# Altium schematic sheet 03_ANALOG.SchDoc
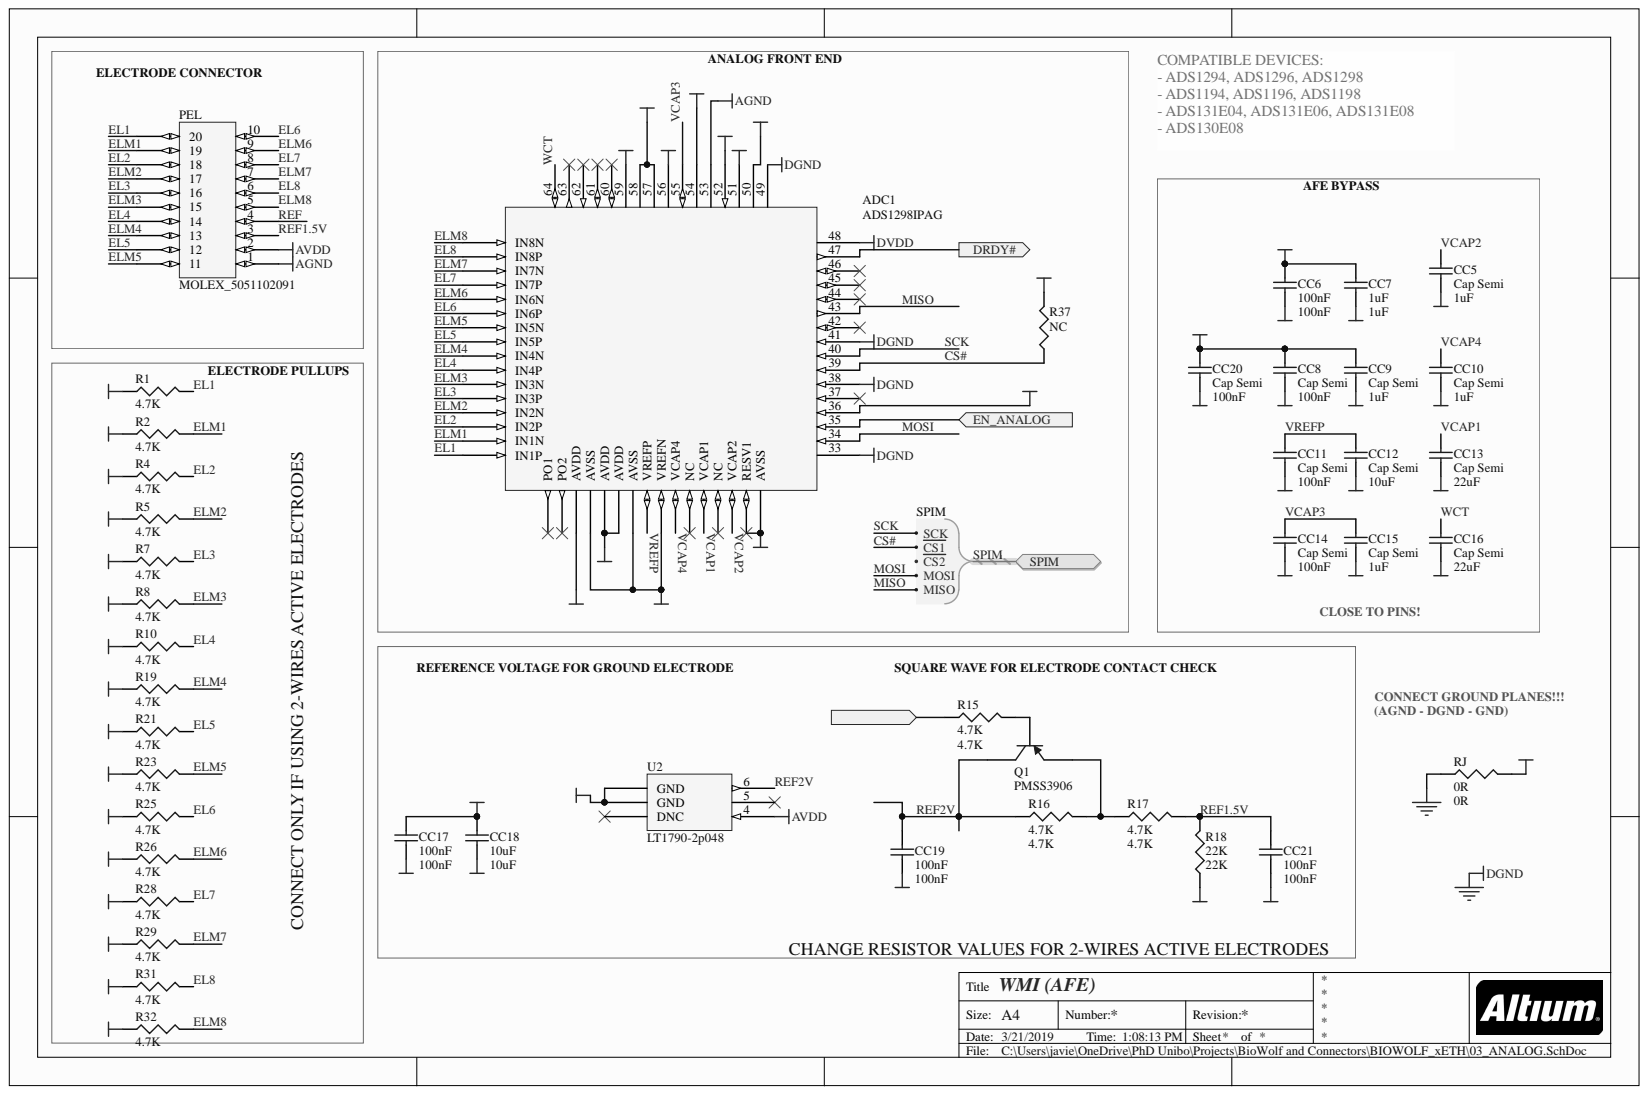
[diagram p0: 03_ANALOG.SchDoc]

## Parts
ADC1  ADS1298IPAG  [LQFP64_M]
CC5  Cap Semi  value 1uF  [0402]
CC6  100nF  [0402]
CC7  1uF  [0402]
CC8  Cap Semi  value 100nF  [0402]
CC9  Cap Semi  value 1uF  [0402]
CC10  Cap Semi  value 1uF  [0402]
CC11  Cap Semi  value 100nF  [0402]
CC12  Cap Semi  value 10uF  [0402]
CC13  Cap Semi  value 22uF  [J1-0603]
CC14  Cap Semi  value 100nF  [0402]
CC15  Cap Semi  value 1uF  [0402]
CC16  Cap Semi  value 22uF  [1608[0603]]
CC17  100nF  [0402]
CC18  10uF  [0402]
CC19  100nF  [0402]
CC20  Cap Semi  value 100nF  [0402]
CC21  100nF  [0402]
PEL  MOLEX_5051102091  [MOLEX_5051102091]
Q1  PMSS3906  [SOT323_N]
R1  4.7K  [0402]
R2  4.7K  [0402]
R4  4.7K  [0402]
R5  4.7K  [0402]
R7  4.7K  [0402]
R8  4.7K  [0402]
R10  4.7K  [0402]
R15  4.7K  [0402]
R16  4.7K  [0402]
R17  4.7K  [0402]
R18  22K  [0402]
R19  4.7K  [0402]
R21  4.7K  [0402]
R23  4.7K  [0402]
R25  4.7K  [0402]
R26  4.7K  [0402]
R28  4.7K  [0402]
R29  4.7K  [0402]
R31  4.7K  [0402]
R32  4.7K  [0402]
R37  NC  [0402]
RJ  0R  [0402]
U2  LT1790-2p048  [SOT-23_A_N]

## Ports
DC_PULSE, DRDY#, EN_ANALOG, SPIM, SPIM.MISO, SPIM.MOSI, SPIM.SCK, SPIM.~{CS1}, SPIM.~{CS2}

## Nets
AGND: ADC1.20(AVSS) ADC1.23(AVSS) ADC1.25(VREFN) ADC1.31(RESV1) ADC1.32(AVSS) ADC1.53 ADC1.57 ADC1.58 CC5.1 CC8.1 CC9.1 CC10.1 CC11.1 CC12.1 CC13.1 CC14.1 CC15.1 CC16.1 CC17.1 CC18.1 CC19.1 CC20.1 CC21.1 PEL.1 R18.1 RJ.2 U2.1(GND) U2.2(GND)
AVDD: ADC1.19(AVDD) ADC1.21(AVDD) ADC1.22(AVDD) ADC1.54 ADC1.56 ADC1.59 CC8.2 CC9.2 CC17.2 CC18.2 CC20.2 PEL.2 R1.1 R2.1 R4.1 R5.1 R7.1 R8.1 R10.1 R19.1 R21.1 R23.1 R25.1 R26.1 R28.1 R29.1 R31.1 R32.1 U2.4
DGND: ADC1.33 ADC1.38 ADC1.41 ADC1.49 ADC1.51 CC6.1 CC7.1 RJ.1
DVDD: ADC1.36 ADC1.48 ADC1.50 ADC1.52 CC6.2 CC7.2 R37.2
CS#: ADC1.39 R37.1 ; port SPIM
DC_PULSE: R15.1 ; port DC_PULSE
DRDY#: ADC1.47 ; port DRDY#
EL1: ADC1.16(IN1P) PEL.20 R1.2
EL2: ADC1.14(IN2P) PEL.18 R4.2
EL3: ADC1.12(IN3P) PEL.16 R7.2
EL4: ADC1.10(IN4P) PEL.14 R10.2
EL5: ADC1.8(IN5P) PEL.12 R21.2
EL6: ADC1.6(IN6P) PEL.10 R25.2
EL7: ADC1.4(IN7P) PEL.8 R28.2
EL8: ADC1.2(IN8P) PEL.6 R31.2
ELM1: ADC1.15(IN1N) PEL.19 R2.2
ELM2: ADC1.13(IN2N) PEL.17 R5.2
ELM3: ADC1.11(IN3N) PEL.15 R8.2
ELM4: ADC1.9(IN4N) PEL.13 R19.2
ELM5: ADC1.7(IN5N) PEL.11 R23.2
ELM6: ADC1.5(IN6N) PEL.9 R26.2
ELM7: ADC1.3(IN7N) PEL.7 R29.2
ELM8: ADC1.1(IN8N) PEL.5 R32.2
EN_ANALOG: ADC1.35 ; port EN_ANALOG
MISO: ADC1.43 ; port SPIM
MOSI: ADC1.34 ; port SPIM
REF: PEL.4
REF1.5V: CC21.2 PEL.3 R17.2 R18.2
REF2V: CC19.2 Q1.3 R16.1 U2.6
SCK: ADC1.40 ; port SPIM
VCAP1: ADC1.28(VCAP1) CC13.2
VCAP2: ADC1.30(VCAP2) CC5.2
VCAP3: ADC1.55 CC14.2 CC15.2
VCAP4: ADC1.26(VCAP4) CC10.2
VREFP: ADC1.24(VREFP) CC11.2 CC12.2
WCT: ADC1.64 CC16.2
NetQ1_1: Q1.1 R15.2
NetQ1_2: Q1.2 R16.2 R17.1
SPIM.~{CS2}: ; port SPIM

Not connected: ADC1 17(PO1) 18(PO2) 27(NC) 29(NC) 37 42 44 45 46 60 61 62 63; U2 3(DNC) 5

## Library data of the parts
ADC1 = ADS1298: Component Kind: Standard; Library Name: Schlib1.SchLib
CC5-CC21 = Cap Semi: Capacitor (Semiconductor SIM Model); Component Kind: Standard; LatestRevisionDate: 29-May-2009; LatestRevisionNote: IPC-7351 Footprint Added.; Library Name: Miscellaneous Devices.IntLib; PackageDescription: Chip Capacitor; PackageReference: C1206; Published: 8-Jun-2000; Signal Integrity: Cap Semi; Simulation: CAP; Publisher: Altium Limited
  CC6 CC17 CC19 CC21: Value: 100nF
  CC7: Value: 1uF
  CC18: Value: 10uF
Q1 = PMSS3906: PNP Switching Transistor; Component Kind: Standard; ComponentLink1Description: Manufacturer Link; ComponentLink1URL: http://www.semiconductors.philips.com/; ComponentLink2Description: Datasheet; ComponentLink2URL: http://www.semiconductors.philips.com/acrobat/datasheets/PMSS3906_4.pdf; DatasheetVersion: 22-Apr-1999; LatestRevisionDate: 12-Dec-2006; LatestRevisionNote: IPC-7351 Footprint Added.; Library Name: Philips Discrete BJT - Low Power.IntLib; PackageDescription: 3-Pin SOT-323 Package 0.65 mm Pitch, 2.1 mm Lead Span; PackageReference: SOT323; PackageVersion: Apr-1999; Published: 17-Sep-2003; Signal Integrity: PMSS3906; Simulation: QPMSS3906; Publisher: Altium Limited
R1 R2 R4 R5 R7 R8 R10 R15-R19 R21 R23 R25 R26 R28 R29 R31 R32 R37 RJ = Res3: Resistor; Component Kind: Standard; LatestRevisionDate: 29-May-2009; LatestRevisionNote: IPC-7351 Footprint Added.; Library Name: Miscellaneous Devices.IntLib; PackageDescription: Chip Resistor; PackageReference: J1-0603; Published: 8-Jun-2000; Signal Integrity: Res; Simulation: RESISTOR; Publisher: Altium Limited
  R1 R2 R4 R5 R7 R8 R10 R15-R17 R19 R21 R23 R25 R26 R28 R29 R31 R32: Value: 4.7K
  R18: Value: 22K
  R37: Value: NC
  RJ: Value: 0R
U2 = LT1790: Component Kind: Standard; Library Name: Schlib1.SchLib
PEL = MOLEX_5051102091: Component Kind: Standard; Library Name: Schlib1.SchLib
